# Altium schematic sheet Sheet3.SchDoc
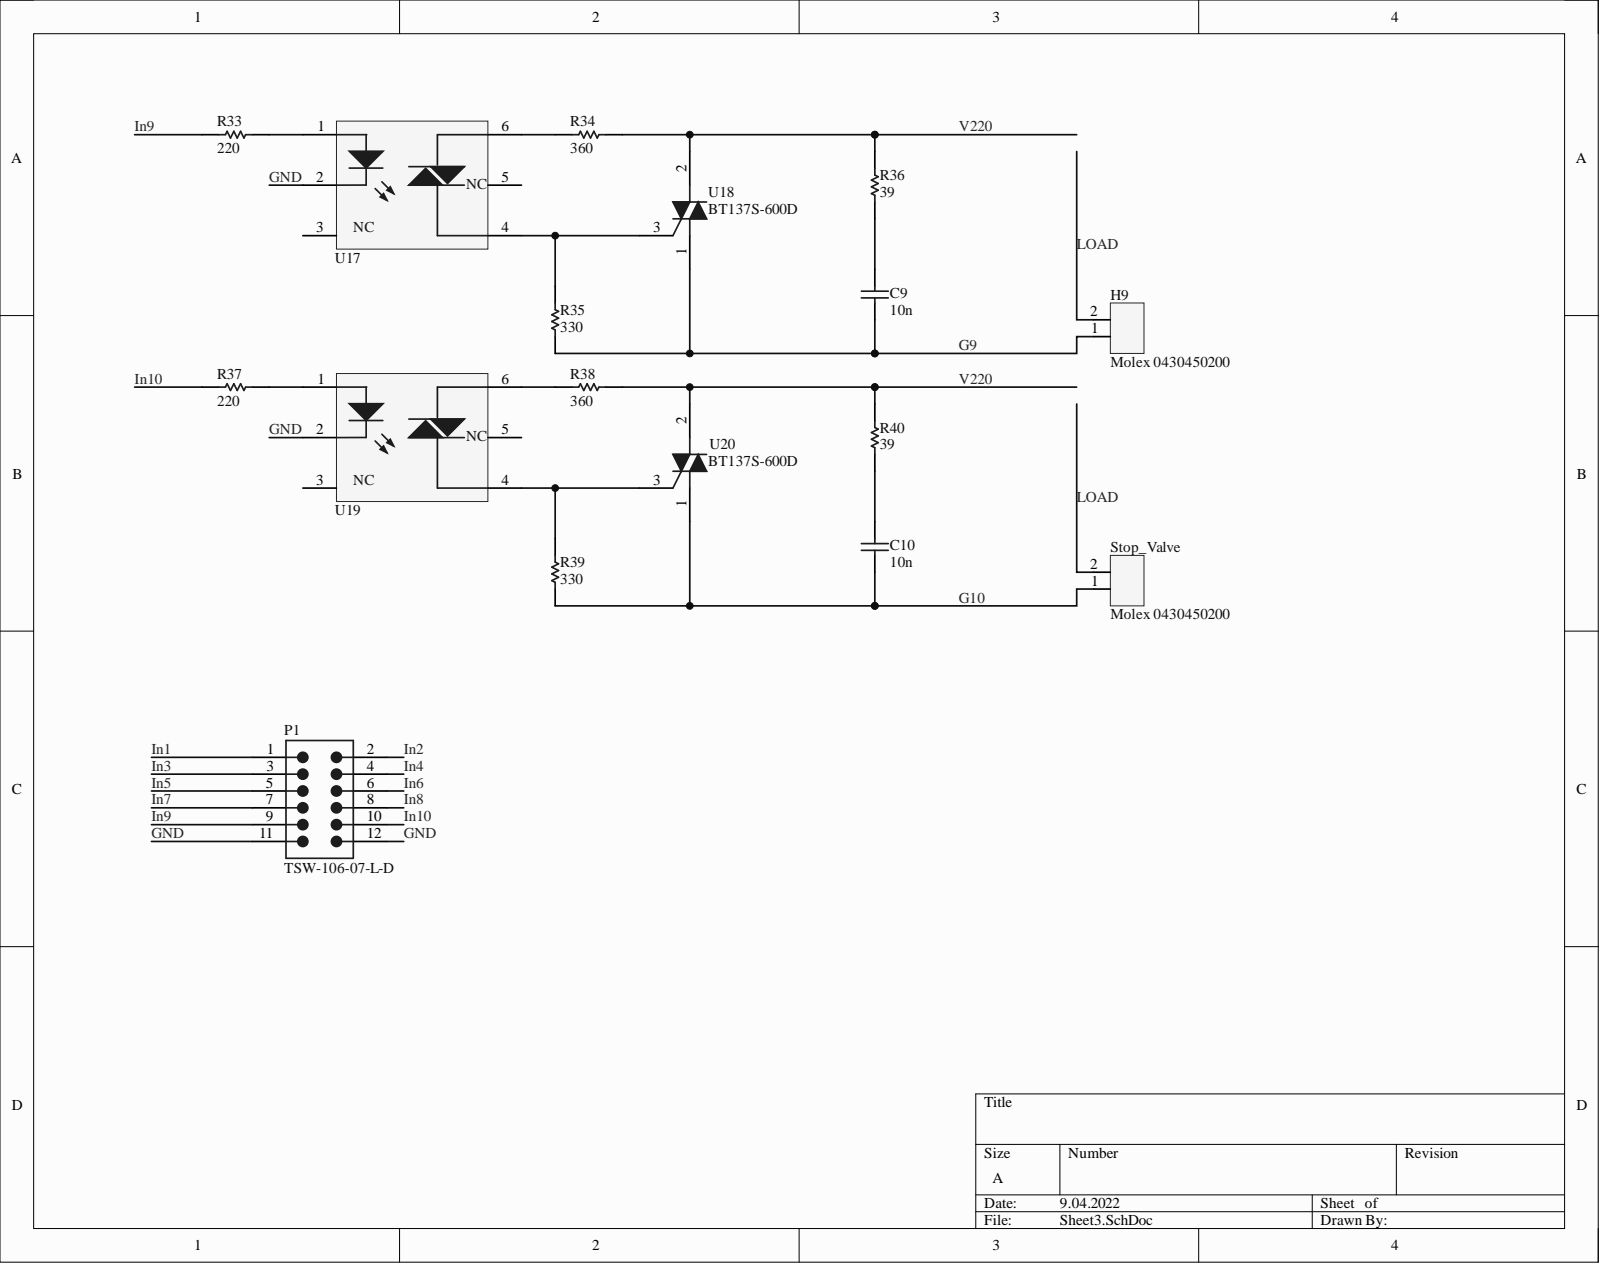
[diagram p0: Sheet3.SchDoc]

## Parts
C9  10n  [PCB-vcjr8nxpwfuh09uwlcd6-1]
C10  10n  [PCB-vcjr8nxpwfuh09uwlcd6-1]
H9  Molex 0430450200  Manufacturer Part Number: 0430450200  [PCB-lpc9z9t8uewl5xeystzy-1]
P1  TSW-106-07-L-D  [SMTC-TSW-106-07-X-D]
R33  220  [RESC2013X50X30ML20T20]
R34  360  [RESC2013X50X30ML20T20]
R35  330  [RESC2013X50X30ML20T20]
R36  39  [RESC2013X50X30ML20T20]
R37  220  [RESC2013X50X30ML20T20]
R38  360  [RESC2013X50X30ML20T20]
R39  330  [RESC2013X50X30ML20T20]
R40  39  [RESC2013X50X30ML20T20]
Stop_Valve  Molex 0430450200  Manufacturer Part Number: 0430450200  [PCB-lpc9z9t8uewl5xeystzy-1]
U17  MOC3043  [PCB-723iinp4b8wdj569zabb-1]  (value not drawn)
U18  BT137S-600D  [PCB-lydts9a3iyc23ocvkdlb-1]
U19  MOC3043  [PCB-723iinp4b8wdj569zabb-1]  (value not drawn)
U20  BT137S-600D  [PCB-lydts9a3iyc23ocvkdlb-1]

## Nets
G9: C9.1 H9.1 R35.1 U18.1
G10: C10.1 R39.1 Stop_Valve.1 U20.1
GND: P1.11 P1.12 U17.2 U19.2
In1: P1.1
In2: P1.2
In3: P1.3
In4: P1.4
In5: P1.5
In6: P1.6
In7: P1.7
In8: P1.8
In9: P1.9 R33.1
In10: P1.10 R37.1
LOAD: H9.2 Stop_Valve.2
V220: R34.2 R36.2 R38.2 R40.2 U18.2 U20.2
NetC9_2: C9.2 R36.1
NetC10_2: C10.2 R40.1
NetR33_2: R33.2 U17.1
NetR34_1: R34.1 U17.6
NetR35_2: R35.2 U17.4 U18.3
NetR37_2: R37.2 U19.1
NetR38_1: R38.1 U19.6
NetR39_2: R39.2 U19.4 U20.3

Not connected: U17 3 5(NC); U19 3 5(NC)

## Library data of the parts
C9 C10 = CMP-526e9b1a13078da9-2: CL21 Series 10 nF 50V ±10 % Tolerance X7R SMT Multilayer Ceramic Capacitor; Manufacturer Part Number: CL21B103KBANNNC; Capacitance: 1e-08 F; Capacitance Tolerance: ±10%; Lead-Free Status: Lead Free; Voltage Rating (DC): 50.0 V; Case/Package: 0805; Component Kind: Standard; Dielectric Characteristic: X7R; Library Name: CommunityVault; Mounting Style: Surface Mount; Octopart URL: http://octopart.com/cl21b103kbannnc-samsung-8283151; OctopartID: 526e9b1a13078da9; RoHS: Compliant
H9 Stop_Valve = CMP-b9e170746c1a6626-2: Conn Wire to Board HDR 2 POS Solder RA Thru-Hole Micro-Fit 3.0Ã¢âÂ¢ Tray; Component Kind: Standard; Contact Plating: Tin; Flammability Rating: UL 94 V-0; Library Name: CommunityVault; Lifecycle Status: Not Listed by Manufacturer; Octopart URL: http://octopart.com/0430450200-molex-10481821; OctopartID: b9e170746c1a6626; Orientation: Right Angle; RoHS: Compliant
P1 = CMP-4485796-2: 0.025" SQ Post Header, Through-hole, Vertical, -55 to 125 degC, 2.54 mm Pitch, 12-Pin, Male, RoHS; Lead-Free Status: Lead Free; Number of Contacts: 12; Pin Pitch: 0.00254 m; Component Kind: Standard; Contact Material: Phosphor Bronze; Contact Plating: Gold; Gender: Male; Housing Color: Black; Housing Material: Plastic; Library Name: CommunityVault; Mounting Style: Through Hole; Number of Positions: 12; Number of Rows: 2; Octopart Url: https://octopart.com/tsw-106-07-l-d-samtec-272251; OctopartID: eac123cfe8dc3506; REACH SVHC Compliance: No SVHC; RoHS: Compliant; Size-Height: 0.00254 m; Size-Length: 0.01524 m; Size-Width: 0.00508 m
R33-R40 = CMP-1857726-2: Res Thick Film 0805 100K Ohm 1% 1/8W ±100ppm/C Molded SMD Paper T/R; Case/Package (SI): 2012; Lead-Free Status: Lead Free; Power Rating: 0.125 W; Resistance: 100000.0 Ω; Temperature Coefficient: 100.0 ppm/°C; Voltage Rating (DC): 150.0 V; Case/Package: 0805; Component Kind: Standard; Library Name: CommunityVault; Mounting Style: Surface Mount; Number of Pins: 2; Octopart Url: https://octopart.com/crcw0805100kfkea-vishay-39456141; OctopartID: 6231a9614adabdf6; REACH SVHC Compliance: No SVHC; Resistance Tolerance: ±1%; RoHS: Compliant; Size-Height: 0.00045 m; Size-Length: 0.002 m; Size-Width: 0.00125 m
U17 U19 = CMP-2a1be31bc7c9f5dc-2: OPTOCOUPLER, TRANSISTOR O/P; No. of Channels: 1; Voltage, Isolation: 5300V; Output Type: Transistor; Input Current: 6...; Manufacturer Part Number: 4N25SR2VM; Lead-Free Status: Lead Free; Number of Channels: 1; Output Voltage: V; Rise Time: 2e-06 s; Input Current: 0.01 A; Input Voltage (DC): 1.18 V; Isolation Voltage: 5300.0 V; Component Kind: Standard; Library Name: FavoritesLibrary; Lifecycle Status: Active; Mounting Style: Surface Mount; Number of Circuits: 1; Number of Pins: 6; Octopart URL: http://octopart.com/4n25sr2vm-fairchild+semiconductor-706740; OctopartID: 2a1be31bc7c9f5dc; Packaging: Tape & Reel (TR); REACH SVHC Compliance: No SVHC; RoHS: Compliant
U18 U20 = CMP-a42a6dbf3fa07bed-1: Thyristor TRIAC 600V 71A 3-Pin(2+Tab) DPAK T/R; Manufacturer Part Number: BT137S-600D,118; Lead-Free Status: Lead Free; Component Kind: Standard; Library Name: CommunityVault; Lifecycle Status: Active; Mounting Style: Surface Mount; Number of Pins: 3; Octopart URL: http://octopart.com/bt137s-600d%2C118-nxp+semiconductors-10469100; OctopartID: a42a6dbf3fa07bed; Packaging: Cut Tape (CT); REACH SVHC Compliance: No SVHC; RoHS: Compliant
